# Altium schematic sheet BIP39.SchDoc
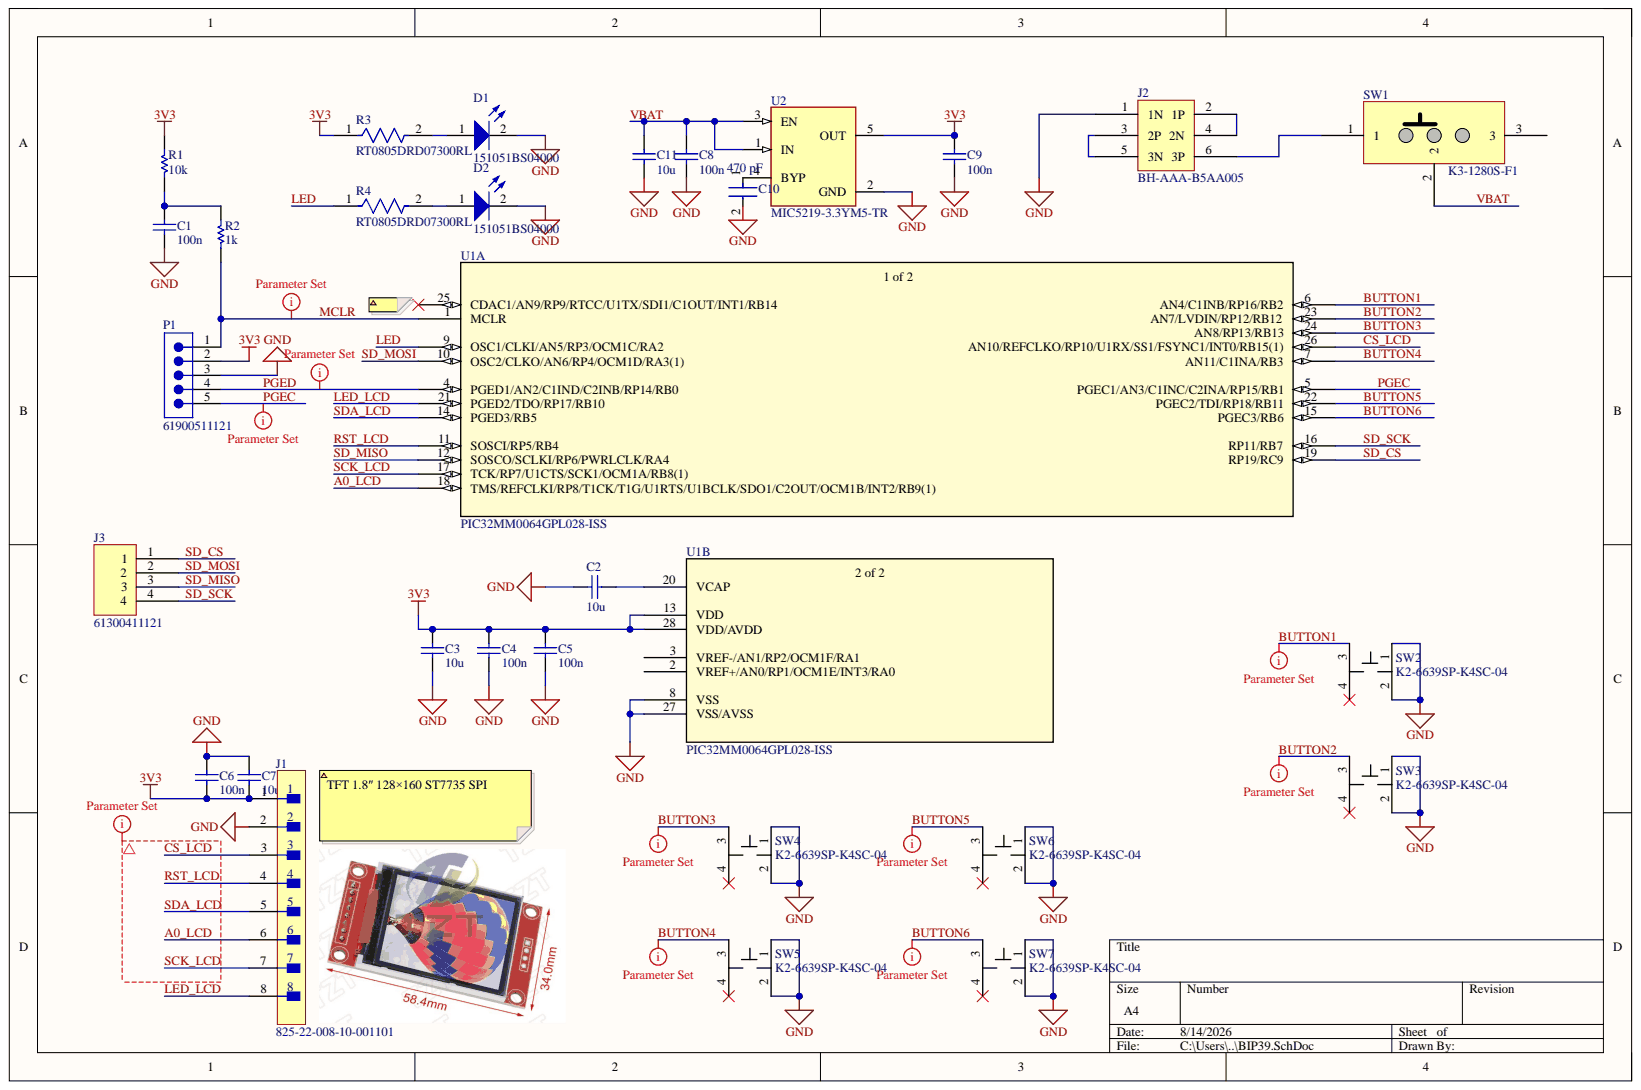
[diagram p0: BIP39.SchDoc]

## Parts
C1  100n  [CC0402]
C2  10u  [CC0805W]
C3  10u  [CC0805W]
C4  100n  [CC0402]
C5  100n  [CC0402]
C6  100n  [CC0402]
C7  10u  [CC0805W]
C8  100n  [CC0402]
C9  100n  [CC0402]
C10  value 470 pF  Capacitance: 470 pF  [FP-C0603C-CF-MFG]  (hidden comment: C0603C471K5RACTU)
C11  10u  [CC0805W]
D1  151051BS04000  [WL-TMRC_D5.9H8.7]
D2  151051BS04000  [WL-TMRC_D5.9H8.7]
J1  825-22-008-10-001101  [FP-825-22-008-10-001101_MFG]
J2  BH-AAA-B5AA005  [BH-AAA-B5AA005]
J3  61300411121  [61300411121]
P1  61900511121  [61900511121]
R1  10k  [RESC1608X04N Vishay FC Series 0603]
R2  1k  [RESC1608X04N Vishay FC Series 0603]
R3  RT0805DRD07300RL  [FP-RT0805-MFG]
R4  RT0805DRD07300RL  [FP-RT0805-MFG]
SW1  K3-1280S-F1  [K3-1280S-F1]
SW2  K2-6639SP-K4SC-04  [K2-6639SP-K4SC-04]
SW3  K2-6639SP-K4SC-04  [K2-6639SP-K4SC-04]
SW4  K2-6639SP-K4SC-04  [K2-6639SP-K4SC-04]
SW5  K2-6639SP-K4SC-04  [K2-6639SP-K4SC-04]
SW6  K2-6639SP-K4SC-04  [K2-6639SP-K4SC-04]
SW7  K2-6639SP-K4SC-04  [K2-6639SP-K4SC-04]
U1  PIC32MM0064GPL028-ISS  [SSOP28_SS_MCH]  (value not drawn)  (parts A,B)
U2  MIC5219-3.3YM5-TR  [FP-SOT23-5LD-PL-1-IPC_C]

## Nets
3V3: C3.1 C4.2 C5.2 C6.1 C7.2 C9.2 J1.1 P1.2 R1.2 R3.1 U1.13(VDD) U1.28(VDD/AVDD) U2.5(OUT)
GND: C1.1 C2.2 C3.2 C4.1 C5.1 C6.2 C7.1 C8.1 C9.1 C10.2 C11.2 D1.2 D2.2 J1.2 J2.1(1N) P1.3 SW2.1 SW2.2 SW3.1 SW3.2 SW4.1 SW4.2 SW5.1 SW5.2 SW6.1 SW6.2 SW7.1 SW7.2 U1.8(VSS) U1.27(VSS/AVSS) U2.2(GND)
A0_LCD: J1.6 U1.18(TMS/REFCLKI/RP8/T1CK/T1G/U1RTS/U1BCLK/SDO1/C2OUT/OCM1B/INT2/RB9(1))
BUTTON1: SW2.3 U1.6(AN4/C1INB/RP16/RB2)
BUTTON2: SW3.3 U1.23(AN7/LVDIN/RP12/RB12)
BUTTON3: SW4.3 U1.24(AN8/RP13/RB13)
BUTTON4: SW5.3 U1.7(AN11/C1INA/RB3)
BUTTON5: SW6.3 U1.22(PGEC2/TDI/RP18/RB11)
BUTTON6: SW7.3 U1.15(PGEC3/RB6)
CS_LCD: J1.3 U1.26(AN10/REFCLKO/RP10/U1RX/SS1/FSYNC1/INT0/RB15(1))
LED: R4.1 U1.9(OSC1/CLKI/AN5/RP3/OCM1C/RA2)
LED_LCD: J1.8 U1.21(PGED2/TDO/RP17/RB10)
MCLR: P1.1 R2.2 U1.1(MCLR)
PGEC: P1.5 U1.5(PGEC1/AN3/C1INC/C2INA/RP15/RB1)
PGED: P1.4 U1.4(PGED1/AN2/C1IND/C2INB/RP14/RB0)
RST_LCD: J1.4 U1.11(SOSCI/RP5/RB4)
SCK_LCD: J1.7 U1.17(TCK/RP7/U1CTS/SCK1/OCM1A/RB8(1))
SD_CS: J3.1 U1.19(RP19/RC9)
SD_MISO: J3.3 U1.12(SOSCO/SCLKI/RP6/PWRLCLK/RA4)
SD_MOSI: J3.2 U1.10(OSC2/CLKO/AN6/RP4/OCM1D/RA3(1))
SD_SCK: J3.4 U1.16(RP11/RB7)
SDA_LCD: J1.5 U1.14(PGED3/RB5)
VBAT: C8.2 C11.1 SW1.2 U2.1(IN) U2.3(EN)
NetC1_2: C1.2 R1.1 R2.1
NetC2_1: C2.1 U1.20(VCAP)
NetC10_1: C10.1 U2.4(BYP)
NetD1_1: D1.1 R3.2
NetD2_1: D2.1 R4.2
NetJ2_2: J2.2(1P) J2.4(2N)
NetJ2_3: J2.3(2P) J2.5(3N)
NetJ2_6: J2.6(3P) SW1.1

Not connected: SW1 3; SW2 4; SW3 4; SW4 4; SW5 4; SW6 4; SW7 4; U1 2(VREF+/AN0/RP1/OCM1E/INT3/RA0) 3(VREF-/AN1/RP2/OCM1F/RA1) 25(CDAC1/AN9/RP9/RTCC/U1TX/SDI1/C1OUT/INT1/RB14)

## Library data of the parts
C1 C4-C6 C8 C9 = GRM152R61A104KE19D: Cap Ceramic 0.1uF 10V X5R 10% SMD 0402 85C Paper T/R; Manufacturer: Murata; Capacitance: 100nF; SENET PIN: C02000813.01; Case Code (Imperial): 0402; Case Code (Metric): 1005; Case/Package: 0402; Category: Ceramic Capacitors; Component Kind: Standard; Depth: 0.5mm; Dielectric: X5R; Height: 0.2mm; Length: 1mm; Library Name: Componentes_local.SchLib; Max Operating Temperature: 85°C; Min Operating Temperature: -55°C; Mount: Surface Mount; Package Quantity: 20000; Packaging: Tape and Reel; Part Number: GRM152R61A104KE19D; Pricing 1: 1=0.18, 10=0.124, 50=0.124, 100=0.063, 1000=0.038, 10000=0.027 (USD); Stock 1: 999193; Supplier 1: Mouser; Supplier Part Number 1: 81-GRM152R61A104KE9D; Termination: SMD/SMT; Thickness: 0.009inch; Tolerance: 10%; Voltage Rating: 10V; Voltage Rating (DC): 10V; Weight: 0.000212oz; Width: 0.5mm
C2 C3 C7 C11 = GRM21BR61E106KA73K: CAP CER 10UF 25V X5R 0805; Manufacturer: Murata; SENET PIN: C02000796.01; Tolerance: 10%; Capacitance: 10uF; Case/Package: 0805; Category: Ceramic Capacitors; Component Kind: Standard; Dielectric: X5R; Length: 0.079inch; Library Name: Componentes_local.SchLib; Manufacturer Part Number: GRM21BR61E106KA73K; Max Operating Temperature: 85°C; Min Operating Temperature: -55°C; Mount: Surface Mount; Package Quantity: 10000; Packaging: Tape and Reel; Part Number: GRM21BR61E106KA73K; Pricing 1: 1=0.43, 10=0.296, 100=0.1773, 500=0.133, 1000=0.11231, 2500=0.1064, 5000=0.10049 (USD); Stock 1: 96729; Supplier 1: Digi-Key; Supplier Part Number 1: 490-16824-6-ND; Thickness: 0.055inch; Voltage Rating: 25V; Voltage Rating (DC): 25V; Weight: 0.000335oz; Width: 0.049inch
C10 = CMP-2006-03816-2: CAP CER 470PF 50V X7R 0603; Manufacturer: KEMET; Automotive Grade: Grade 1; Material: Ceramic; Temperature Coefficient: 30ppm/°C; Tolerance: 0.1; Automotive: Yes; Category: Cap; Component Kind: Standard; ComponentLink2Description: Datasheet; ComponentLink2URL: https://api.kemet.com/component-edge/download/datasheet/C0603C100J5GACAUTO.pdf; Device Class L1: Passive Components; Device Class L2: Capacitors; Device Class L3: Ceramic Capacitors; Digikey Description: CAP CER 470PF 50V X7R 0603; Height: 0.87mm; Lead Free: Yes; Library Name: Altium Content Vault; Mouser Description: Ceramic Capacitor, 470Pf, 50V, X7R, 10%, 0603, Full Reel; Octopart: https://octopart.com/c0603c471k5ractu-kemet-89311; Package: C0603C-CF; Part Number: C0603C471K5RACTU; RoHS: TRUE; Temperature: -55°C to +125°C; Temperature Characteristic: X7R; Temperature Range High: +125°C; Temperature Range Low: -55°C; Voltage Rating: 50 V
D1 D2 = CMP-1488-00009-2: NexarPartId: 29717812; Color: Blue; Dominant Wavelength: 470nm; Forward Current: 100mA; Forward Voltage: 3.2V; Lens Size: 5mm; Lens Style: Circular, Diffused, Round; Luminous Intensity: 500mcd; Max Reverse Voltage (DC): 5V; Peak Wavelength: 465nm; Test Current: 20mA; Viewing Angle: 40°; Case/Package: Radial; Component Kind: Standard; Height: 8.6mm; Length: 5mm; Library Name: Altium Content Vault; Max Operating Temperature: 85°C; Min Operating Temperature: -40°C; Mount: Through Hole; Packaging: Bulk; Part Number: 151051BS04000; REACH SVHC: Unknown; RoHS: Compliant; Wavelength: 470nm; Width: 5mm
J1 = CMP-00254-10533681-1: Headers & Wire Housings SPRING LOADED HEADER 1R 8P VERT MNT TH; Current Rating: 2A; Number of Contacts: 8; Pitch: 2.54mm; Voltage Rating: 150V; Component Kind: Standard; Contact Gender: Male; Contact Material: Gold, Nickel; Contact Plating: Gold; Height: 7.92mm; Lead Free: Lead Free; Library Name: Altium Content Vault; Max Operating Temperature: 125°C; Min Operating Temperature: -55°C; Number of Positions: 8; Number of Rows: 1; REACH SVHC: No SVHC; RoHS: Compliant; Row Spacing: 2.54mm; Termination: Through Hole
J3 = 61300411121: Board-To-Board Connector, Vertical, WR-PHD Series, Through Hole, Header, 4 Contacts, 2.54 mm; Manufacturer: Wurth Electronics; Connector Type: Male Pin; Pitch: 0.1inch; SENET PIN: C03001375.01; Category: Connectors, Interconnects; Component Kind: Standard; Lead Free: Lead Free; Library Name: Componentes_local.SchLib; Mount: Through Hole; Packaging: Bulk; Part Number: 61300411121; REACH SVHC: Unknown; Stock 1: 0; Supplier 1: Mouser; Supplier Part Number 1: 61300411121; Termination: Solder
P1 = CMP-1502-00587-2: NexarPartId: 18818230; Connector Type: Male Pin; Contact Resistance: 20mΩ; Current Rating: 3A; Gender: Male; Insulation Resistance: 1GΩ; Number of Contacts: 5; Operating Supply Voltage: 250V; Pitch: 2.54mm; Color: Beige; Component Kind: Standard; Contact Gender: Male; Contact Material: Copper; Contact Mating Length: 7.493mm; Contact Plating: Tin; Fastening Type: Friction; Flammability Rating: UL94 V-0; Height: 12.5mm; Housing Material: Nylon; Lead Free: Lead Free; Lead Pitch: 2.54mm; Length: 12.7mm; Library Name: Altium Content Vault; Max Operating Temperature: 105°C; Min Operating Temperature: -40°C; Mount: Through Hole; Number of Pins: 5; Number of Positions: 5; Number of Rows: 1; Orientation: Vertical; Packaging: Bulk; Part Number: 61900511121; REACH SVHC: Unknown; RoHS: Compliant; Termination: Through Hole; Voltage Rating: 250V; Width: 12.74mm
R1 = CRCW060310K0FKEA: RES SMD 10K OHM 1% 1/10W 0603; Manufacturer: Vishay; Max Power Dissipation: 100mW; Resistance: 10kR; SENET PIN: C12001564.01; Temperature Coefficient: 100ppm/°C; Voltage Rating: 75V; Case Code (Imperial): 0603; Case Code (Metric): 1608; Case/Package: 0603; Category: Resistors; Component Kind: Standard; ComponentLink1Description: http://www.vishay.com/docs/20008/dcrcw.pdf?src-supplier=Avnet+Europe; ComponentLink1URL: http://www.vishay.com/docs/20008/dcrcw.pdf?src-supplier=Avnet+Europe; ComponentLink2Description: https://www.verical.com/server-webapp/html/getDatasheet?partID=86831&src-supplier=Verical; ComponentLink2URL: https://www.verical.com/server-webapp/html/getDatasheet?partID=86831&src-supplier=Verical; ComponentLink3Description: http://www.farnell.com/datasheets/2310790.pdf?src-supplier=Allied+Electronics; ComponentLink3URL: http://www.farnell.com/datasheets/2310790.pdf?src-supplier=Allied+Electronics; ComponentLink4Description: http://www.vishay.com/docs/20035/dcrcwe3.pdf?src-supplier=Digi-Key; ComponentLink4URL: http://www.vishay.com/docs/20035/dcrcwe3.pdf?src-supplier=Digi-Key; ComponentLink5Description: http://www.farnell.com/datasheets/2310790.pdf?src-supplier=Mouser; ComponentLink5URL: http://www.farnell.com/datasheets/2310790.pdf?src-supplier=Mouser; ComponentLink6Description: http://www.farnell.com/datasheets/2111203.pdf?src-supplier=Element14; ComponentLink6URL: http://www.farnell.com/datasheets/2111203.pdf?src-supplier=Element14; ComponentLink7Description: http://www.farnell.com/datasheets/2310790.pdf?src-supplier=Avnet; ComponentLink7URL: http://www.farnell.com/datasheets/2310790.pdf?src-supplier=Avnet; ComponentLink8Description: http://www.vishay.com/docs/20035/dcrcwe3.pdf?src-supplier=Future+Electronics; ComponentLink8URL: http://www.vishay.com/docs/20035/dcrcwe3.pdf?src-supplier=Future+Electronics; ComponentLink9Description: http://uk.rs-online.com/webdocs/0d98/0900766b80d98afc.pdf?src-supplier=RSComponents; ComponentLink9URL: http://uk.rs-online.com/webdocs/0d98/0900766b80d98afc.pdf?src-supplier=RSComponents; ComponentLink10Description: http://www.farnell.com/datasheets/2310790.pdf?src-supplier=Distrelec; ComponentLink10URL: http://www.farnell.com/datasheets/2310790.pdf?src-supplier=Distrelec; Composition: Thick Film; Depth: 0.85mm; Features: Automotive AEC-Q200; Height: 0.45mm; Lead Free: Lead Free; Length: 1.55mm; Library Name: Componentes_local.SchLib; Max Operating Temperature: 155°C; Min Operating Temperature: -55°C; Package Quantity: 10; Packaging: Tape and Reel; Part Number: CRCW060310K0FKEA; Power Rating: 100mW; Pricing 1: 1=0.1, 10=0.04, 50=0.04, 100=0.028, 1000=0.011, 10000=0.007 (USD); Radiation Hardening: No; REACH SVHC: Unknown; Stock 1: 0; Supplier 1: Mouser; Supplier Part Number 1: 71-CRCW0603-10K-E3; Termination: SMD/SMT; Tolerance: 1%; Voltage Rating (DC): 75V; Weight: 7.1E-05oz; Width: 0.033inch
R2 = CRCW06031K00FKEA: RES SMD 1K OHM 1% 1/10W 0603; Manufacturer: Vishay Dale; Max Power Dissipation: 100mW; Resistance: 1kR; SENET PIN: C12000231.01; Temperature Coefficient: 100ppm/°C; Voltage Rating: 75V; Case Code (Imperial): 0603; Case Code (Metric): 1608; Case/Package: 0603; Category: Resistors; Component Kind: Standard; ComponentLink1Description: https://datasheet.ciiva.com/11808/0900766b80d98afc-11808625.pdf?src-supplier=RSComponents; ComponentLink1URL: https://datasheet.ciiva.com/11808/0900766b80d98afc-11808625.pdf?src-supplier=RSComponents; ComponentLink2Description: https://datasheet.ciiva.com/2465/dcrcwe3-2465536.pdf?src-supplier=Digi-Key; ComponentLink2URL: https://datasheet.ciiva.com/2465/dcrcwe3-2465536.pdf?src-supplier=Digi-Key; ComponentLink3Description: https://datasheet.ciiva.com/8336/dcrcwe3-8336822.pdf?src-supplier=Avnet; ComponentLink3URL: https://datasheet.ciiva.com/8336/dcrcwe3-8336822.pdf?src-supplier=Avnet; ComponentLink4Description: https://datasheet.ciiva.com/16515/dcrcwe3-16515078.pdf?src-supplier=Avnet+Asia; ComponentLink4URL: https://datasheet.ciiva.com/16515/dcrcwe3-16515078.pdf?src-supplier=Avnet+Asia; ComponentLink5Description: https://datasheet.ciiva.com/46441/1720486-46441622.pdf?src-supplier=Element14; ComponentLink5URL: https://datasheet.ciiva.com/46441/1720486-46441622.pdf?src-supplier=Element14; ComponentLink6Description: https://datasheet.ciiva.com/26316/fld-crcw-e3-data-en-26316551.pdf?src-supplier=Distrelec; ComponentLink6URL: https://datasheet.ciiva.com/26316/fld-crcw-e3-data-en-26316551.pdf?src-supplier=Distrelec; ComponentLink7Description: https://datasheet.ciiva.com/46441/1720486-46441623.pdf?src-supplier=Element14; ComponentLink7URL: https://datasheet.ciiva.com/46441/1720486-46441623.pdf?src-supplier=Element14; ComponentLink8Description: https://datasheet.ciiva.com/46385/1697337-46385497.pdf?src-supplier=Element14; ComponentLink8URL: https://datasheet.ciiva.com/46385/1697337-46385497.pdf?src-supplier=Element14; ComponentLink9Description: https://datasheet.ciiva.com/3257/dcrcwe3-3257132.pdf?src-supplier=Avnet; ComponentLink9URL: https://datasheet.ciiva.com/3257/dcrcwe3-3257132.pdf?src-supplier=Avnet; ComponentLink10Description: https://datasheet.ciiva.com/16199/dcrcwe3-16199053.pdf?src-supplier=Future+Electronics; ComponentLink10URL: https://datasheet.ciiva.com/16199/dcrcwe3-16199053.pdf?src-supplier=Future+Electronics; ComponentLink11Description: https://datasheet.ciiva.com/2465/dcrcwe3-2465534.pdf?src-supplier=Digi-Key; ComponentLink11URL: https://datasheet.ciiva.com/2465/dcrcwe3-2465534.pdf?src-supplier=Digi-Key; ComponentLink12Description: https://datasheet.ciiva.com/16893/dcrcwe3-16893742.pdf?src-supplier=Avnet+Europe; ComponentLink12URL: https://datasheet.ciiva.com/16893/dcrcwe3-16893742.pdf?src-supplier=Avnet+Europe; ComponentLink13Description: https://datasheet.ciiva.com/2465/dcrcwe3-2465535.pdf?src-supplier=Digi-Key; ComponentLink13URL: https://datasheet.ciiva.com/2465/dcrcwe3-2465535.pdf?src-supplier=Digi-Key; Composition: Thick Film; Depth: 0.85mm; Features: Automotive AEC-Q200; Height: 0.45mm; Lead Free: Lead Free; Length: 1.55mm; Library Name: Componentes_local.SchLib; Max Operating Temperature: 155°C; Min Operating Temperature: -55°C; Mount: Surface Mount; Package Quantity: 10; Packaging: Tape and Reel; Part Number: CRCW06031K00FKEA; Power Rating: 100mW; Pricing 1: 1=0,10000, 10=0,04000, 50=0,04000, 100=0,01600, 1000=0,00800, 10000=0,00600 (USD); Radiation Hardening: No; REACH SVHC: Unknown; RoHS: Yes; Stock 1: 544833; Supplier 1: Mouser; Supplier Part Number 1: 71-CRCW0603-1.0K-E3; Termination: SMD/SMT; Tolerance: 1%; Voltage Rating (DC): 75V; Weight: 7.1E-05oz; Width: 0.033inch
R3 R4 = CMP-03412-013279-1: RES 300 OHM 1/8W .5% SMD 0805; Manufacturer: Yageo; Power Rating: 0.125W; Resistance: 300Ω; Temperature Coefficient: 25 ppm/°C; Tolerance: 0.01; Value: 300Ω; Voltage Rating: 150V; Automotive: No; Category: Res; Component Kind: Standard; ComponentLink2Description: Datasheet; ComponentLink2URL: http://www.yageo.com/documents/recent/PYu-RT_1-to-0.01_RoHS_L_9.pdf; Composition: Thin Film; Device Class L1: Passive Components; Device Class L2: Resistors; Device Class L3: Chip SMD Resistors; Digikey Description: RES 300 OHM 1/8W .5% SMD 0805; Footprint Url: http://www.yageo.com/exep/pages/download/literatures/PYu-R_Mount_10.pdf; Height: 0.6mm; Ipc Land Pattern Name: RESC200125X50; Lead Free: Yes; Library Name: Altium Content Vault; Octopart: https://octopart.com/rt0805drd07300rl-yageo-1243455; Package: RT0805; Part Number: RT0805DRD07300RL; RoHS: TRUE; Series: RT; Temperature: -55°C to +155°C; Temperature Range High: +155°C; Temperature Range Low: -55°C
SW1 = K3-1280S-F1: SPDT 300mA 6V; Component Kind: Standard; JLCPCB Part: C92658; Library Name: personal library.SchLib; Part Number: K3-1280S-F1
U1 = PIC32MM0064GPL028-ISS: No Description Available; Mfr_Name: Microchip; Component Kind: Standard; Library Name: personal library.SchLib; RefDes: RefDes; Type: Embedded
U2 = CMP-06324-000027-1: IC REG LINEAR 3.3V 500MA SOT23-5; Dropout Voltage: 350mV; Max Input Voltage: 12V; Min Input Voltage: 2.5V; Number of Regulators: 1; Output Type: Fixed; Case/Package: SOT-23-5; Component Kind: Standard; Lead Free: Lead Free; Library Name: Altium Content Vault; Max Operating Temperature: 125°C; Min Operating Temperature: -40°C; Mount: Surface Mount; Nominal Output Voltage: 3.3V; Number of Pins: 5; Output Current: 500mA; Output Voltage: 3.3V; Packaging: Cut Tape; Part Number: MIC5219-3.3YM5-TR; REACH SVHC: No SVHC
J2 = BH-AAA-B5AA005: Component Kind: Standard; Library Name: personal library.SchLib
SW2-SW7 = K2-6639SP-K4SC-04: Component Kind: Standard; Library Name: personal library.SchLib
